# Altium schematic sheet DVT-Buffer.SchDoc(DVT-Buffer)
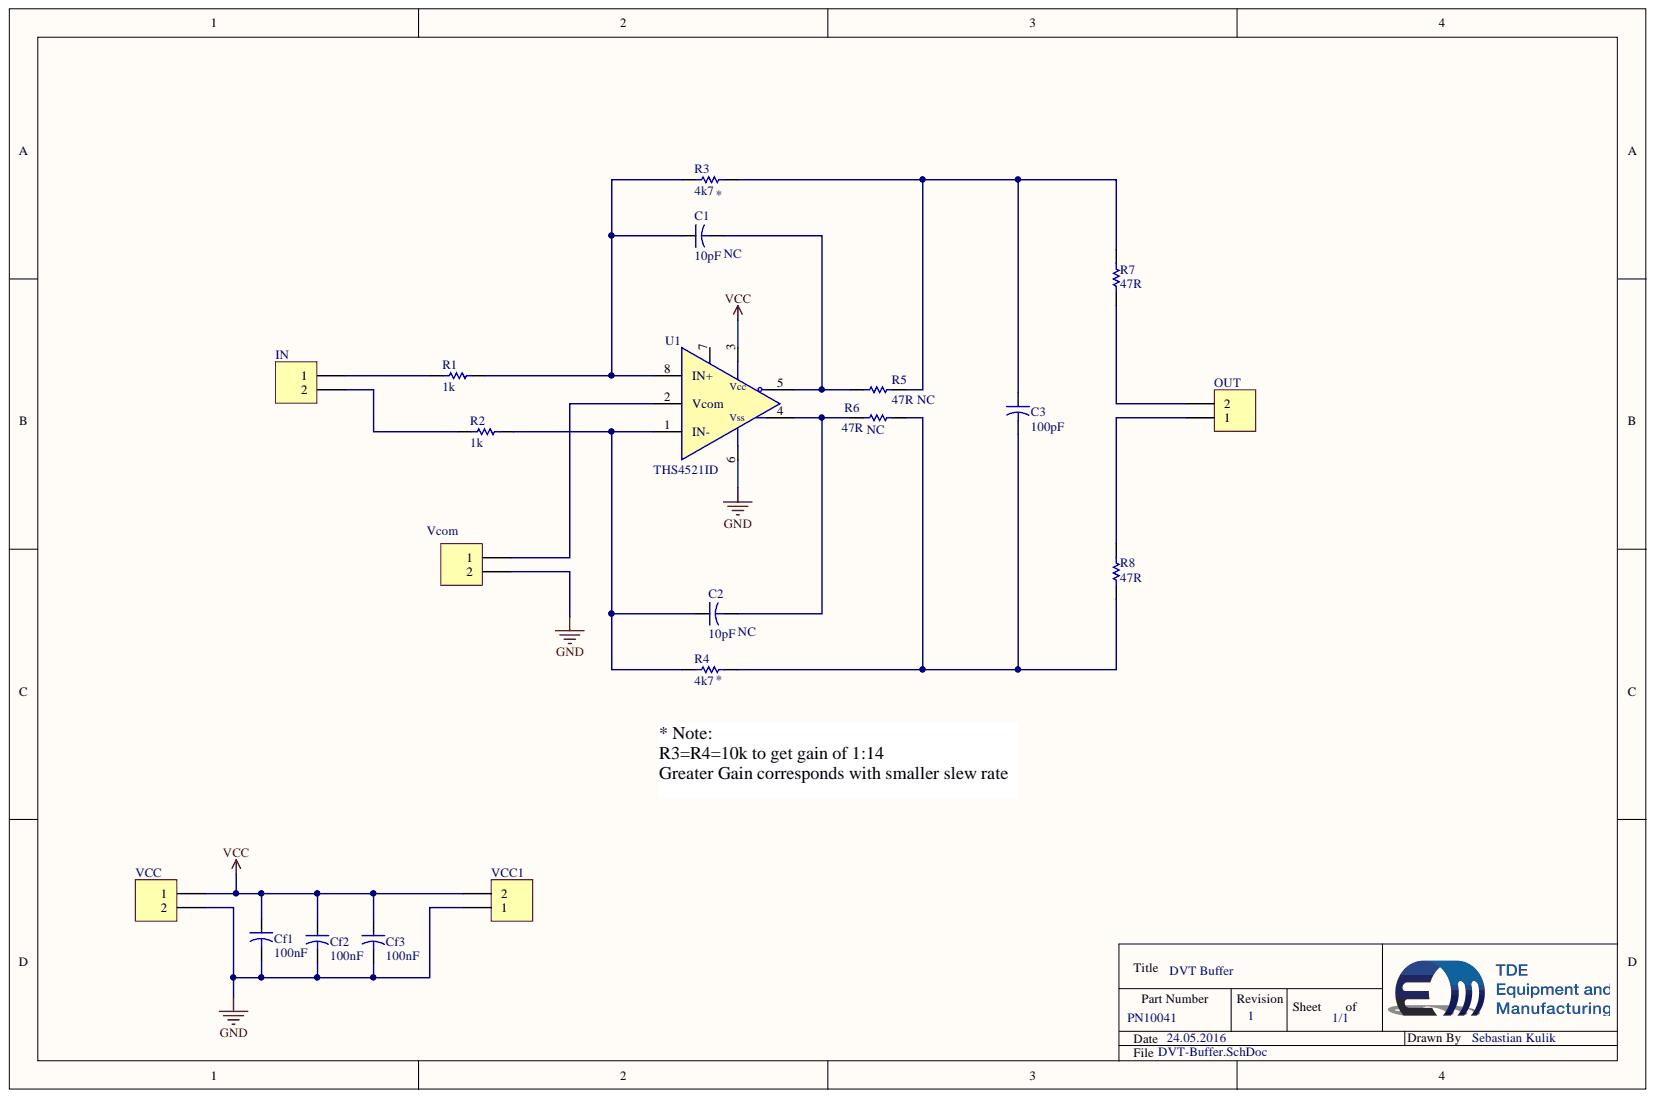
[diagram p0: DVT-Buffer.SchDoc]

## Parts
C1  value 10pF  [Dummy-10mm]  (hidden comment: Capacitor-Dummy-THT)
C2  value 10pF  [Dummy-10mm]  (hidden comment: Capacitor-Dummy-THT)
C3  value 100pF  [Dummy-10mm]  (hidden comment: Capacitor-Dummy-THT)
Cf1  value 100nF  [Dummy-10mm]  (hidden comment: Capacitor-Dummy-THT)
Cf2  value 100nF  [Dummy-10mm]  (hidden comment: Capacitor-Dummy-THT)
Cf3  value 100nF  [Dummy-10mm]  (hidden comment: Capacitor-Dummy-THT)
IN  PHOENIX_1715721  [Phoenix-2-5_08]  (value not drawn)
OUT  PHOENIX_1715721  [Phoenix-2-5_08]  (value not drawn)
R1  value 1k  [Dummy-10mm]  (hidden comment: Resistor-Dummy-THT)
R2  value 1k  [Dummy-10mm]  (hidden comment: Resistor-Dummy-THT)
R3  value 4k7  [Dummy-10mm]  (hidden comment: Resistor-Dummy-THT)
R4  value 4k7  [Dummy-10mm]  (hidden comment: Resistor-Dummy-THT)
R5  value 47R  [Dummy-10mm]  (hidden comment: Resistor-Dummy-THT)
R6  value 47R  [Dummy-10mm]  (hidden comment: Resistor-Dummy-THT)
R7  value 47R  [Dummy-10mm]  (hidden comment: Resistor-Dummy-THT)
R8  value 47R  [Dummy-10mm]  (hidden comment: Resistor-Dummy-THT)
U1  THS4521ID  [TSSOP8]
VCC  PHOENIX_1715721  [Phoenix-2-5_08]  (value not drawn)
VCC1  PHOENIX_1715721  [Phoenix-2-5_08]  (value not drawn)
Vcom  Plug_2_90_2.54  [HDR1X2]  (value not drawn)

## Nets
GND: Cf1.2 Cf2.2 Cf3.2 U1.6 VCC.2 VCC1.1 Vcom.2
VCC: Cf1.1 Cf2.1 Cf3.1 U1.3 VCC.1 VCC1.2
NetC1_1: C1.1 R1.2 R3.1 U1.8(IN+)
NetC1_2: C1.2 R5.1 U1.5(Vcc)
NetC2_1: C2.1 R2.2 R4.1 U1.1(IN-)
NetC2_2: C2.2 R6.1 U1.4(Vss)
NetC3_1: C3.1 R3.2 R5.2 R7.2
NetC3_2: C3.2 R4.2 R6.2 R8.1
NetIN_1: IN.1 R1.1
NetIN_2: IN.2 R2.1
NetOUT_1: OUT.1 R8.2
NetOUT_2: OUT.2 R7.1
NetU1_2: U1.2(Vcom) Vcom.1

Not connected: U1 7

## Library data of the parts
C1 C2 = Capacitor-Dummy-THT: Deliverer PartNr: 538-1146; Deliverer: RS; Component Kind: Standard; Description: Capacitor-Dummy-THT; Library Name: Capacitors.IntLib; Manufacturer: -; Manufacturer PartNr: -; PackageDescription: -; Part Description: Capacitor-Dummy-THT; Price: 0.27/5; Published: 08.12.2015; Signal Integrity: Cap; Simulation: CAP; TDE-PN: -; Publisher: Sebastian Kulik
C3 = Capacitor-Dummy-THT: Deliverer PartNr: 538-1376; Deliverer: RS; Component Kind: Standard; Description: Capacitor-Dummy-THT; Library Name: Capacitors.IntLib; Manufacturer: -; Manufacturer PartNr: -; PackageDescription: -; Part Description: Capacitor-Dummy-THT; Price: 0.238/5; Published: 08.12.2015; Signal Integrity: Cap; Simulation: CAP; TDE-PN: -; Publisher: Sebastian Kulik
Cf1-Cf3 = Capacitor-Dummy-THT: Deliverer PartNr: 537-3707; Deliverer: RS; Component Kind: Standard; Description: Capacitor-Dummy-THT; Library Name: Capacitors.IntLib; Manufacturer: -; Manufacturer PartNr: -; PackageDescription: -; Part Description: Capacitor-Dummy-THT; Price: 0.156/25; Published: 08.12.2015; Signal Integrity: Cap; Simulation: CAP; TDE-PN: -; Publisher: Sebastian Kulik
IN OUT VCC VCC1 = 2Pol_1715721: Phoenix, 2 pol, 5.08mm, 22A, 715721; Deliverer PartNr: 193-0564; Deliverer: RS; TDE-PN: CON0008; Component Kind: Standard; LatestRevisionDate: 02.05.2014; LatestRevisionNote: Re-released for DXP Platform.; Library Name: Connectors.IntLib; Manufacturer: Phoenix; Manufacturer PartNr: 1715721; PackageDescription: Phoenix-2-5_08; Signal Integrity: Connector; Publisher: Sebastian Kulik
  IN OUT: Price: 0.976/5
R1 R2 = Resistor-Dummy-THT: Deliverer PartNr: 148-506; Deliverer: RS; Component Kind: Standard; Description: Resistor-Dummy-THT; Library Name: Resistors.IntLib; Manufacturer: -; Manufacturer PartNr: -; PackageDescription: -; Part Desicription: Resistor-Dummy-THT; Price: 0.027/10; Published: 08.12.2015; Signal Integrity: Res; Simulation: RESISTOR; TDE-PN: -; Publisher: Sebastian Kulik
R3 R4 = Resistor-Dummy-THT: Deliverer PartNr: 148-663; Deliverer: RS; Component Kind: Standard; Description: Resistor-Dummy-THT; Library Name: Resistors.IntLib; Manufacturer: -; Manufacturer PartNr: -; PackageDescription: -; Part Desicription: Resistor-Dummy-THT; Price: 0.046/10; Published: 08.12.2015; Signal Integrity: Res; Simulation: RESISTOR; TDE-PN: -; Publisher: Sebastian Kulik
R5-R8 = Resistor-Dummy-THT: Deliverer PartNr: 148-736; Deliverer: RS; Component Kind: Standard; Description: Resistor-Dummy-THT; Library Name: Resistors.IntLib; Manufacturer: -; Manufacturer PartNr: -; PackageDescription: -; Part Desicription: Resistor-Dummy-THT; Price: 0.027/10; Published: 08.12.2015; Signal Integrity: Res; Simulation: RESISTOR; TDE-PN: -; Publisher: Sebastian Kulik
U1 = THS4521ID: Fully differential OP, 50MHz; Deliverer PartNr: 709-2867; Manufacturer: TI; Deliverer: RS; TDE-PN: IC00073; Component Kind: Standard; Library Name: ICs.IntLib; Manufacturer PartNr: THS4521ID; PackageDescription: TSSOP8; PCB3D: SOP8; Price: 3.29; Published: 15.04.2016; Publisher: Sebastian Kulik
Vcom = Plug_2_90_2.54: Plug, 2-Pin; Deliverer PartNr: 828-1651; Manufacturer: WE; Manufacturer PartNr: 61300211021; Deliverer: RS; TDE-PN: CON0010; Component Kind: Standard; LatestRevisionDate: 14.05.2014; LatestRevisionNote: Re-released for DXP Platform.; Library Name: Connectors.IntLib; Price: 0.306/5; Signal Integrity: Connector; Publisher: Sebastian Kulik
